# Altium schematic sheet BLDC_Motor_Reverser.SchDoc(BLDC_Motor_Reverser)
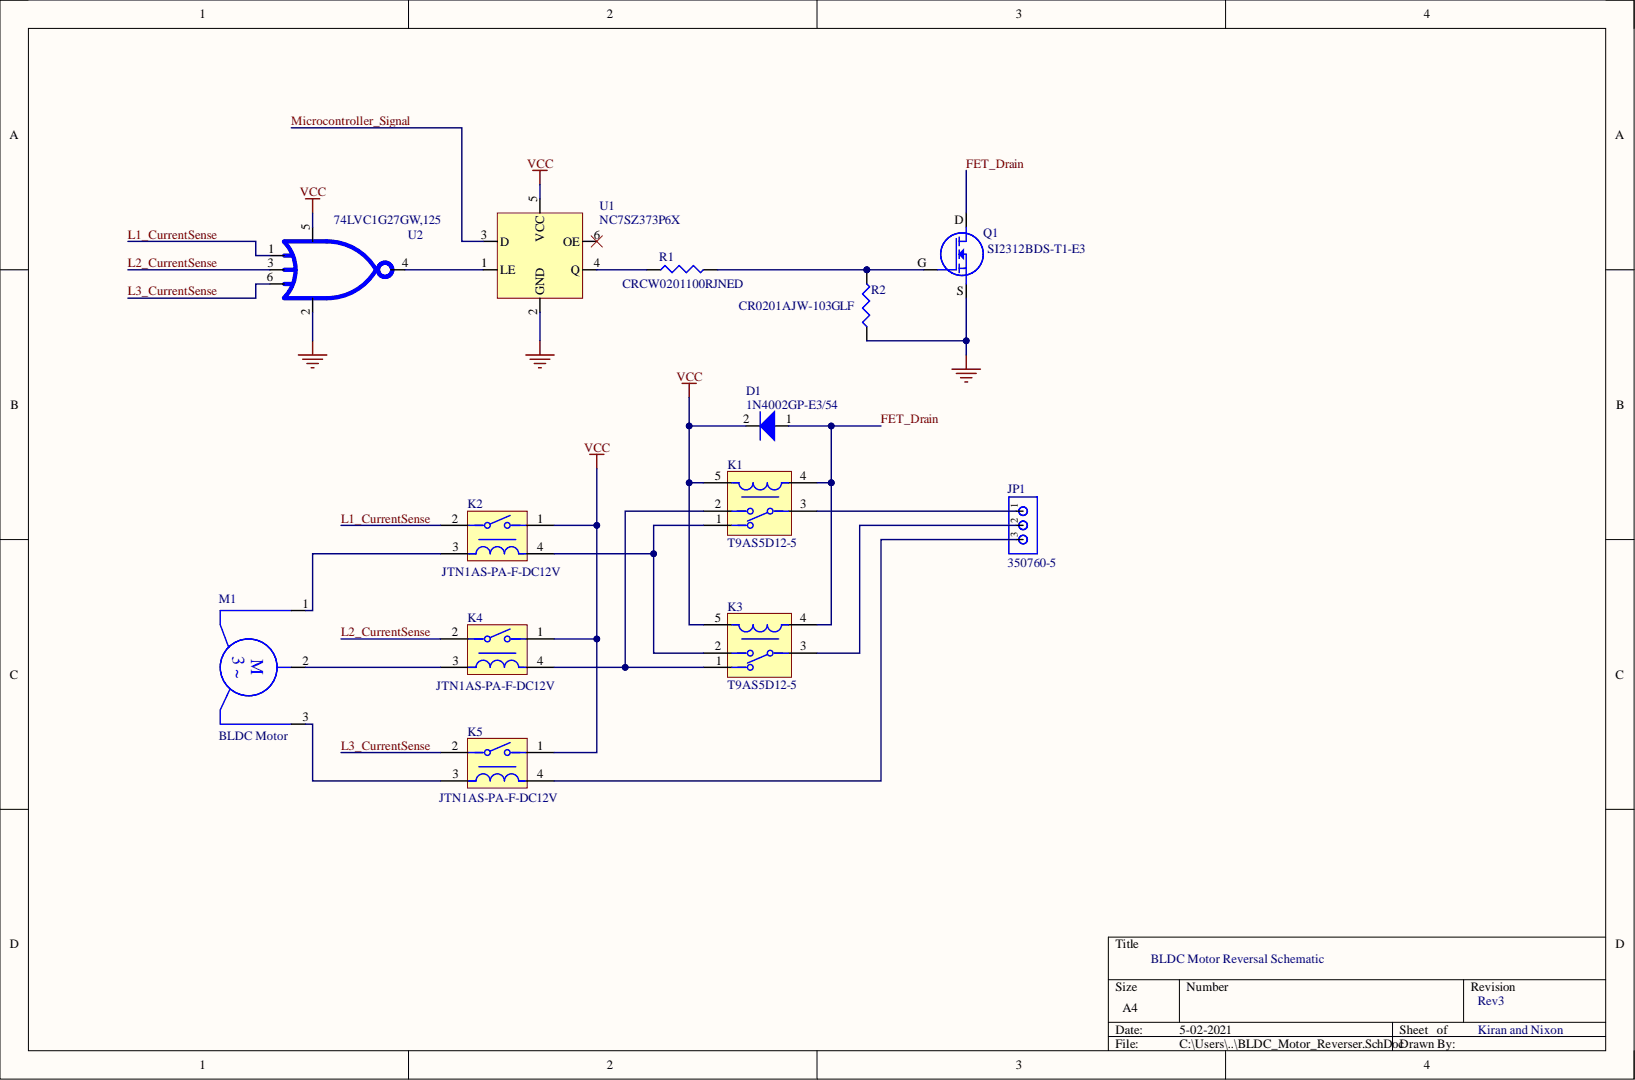
[diagram p0: BLDC_Motor_Reverser.SchDoc]

## Parts
D1  1N4002GP-E3/54
JP1  350760-5
K1  T9AS5D12-5  [FP-T9AS5D12-5-MFG]
K2  JTN1AS-PA-F-DC12V
K3  T9AS5D12-5  [FP-T9AS5D12-5-MFG]
K4  JTN1AS-PA-F-DC12V
K5  JTN1AS-PA-F-DC12V
M1  BLDC Motor
Q1  SI2312BDS-T1-E3
R1  CRCW0201100RJNED
R2  CR0201AJW-103GLF
U1  NC7SZ373P6X
U2  74LVC1G27GW,125

## Nets
GND: Q1.S R2.1 U1.2(GND) U2.2
VCC: D1.2 K1.5 K2.1 K3.5 K4.1 K5.1 U1.5(VCC) U2.5
FET_Drain: D1.1 K1.4 K3.4 Q1.D
L1_CurrentSense: K2.2 U2.1
L2_CurrentSense: K4.2 U2.3
L3_CurrentSense: K5.2 U2.6
Microcontroller_Signal: U1.3(D)
NetJP1_1: JP1.1 K1.3
NetJP1_2: JP1.2 K3.3
NetJP1_3: JP1.3 K5.4
NetK1_1: K1.1 K2.4 K3.2
NetK1_2: K1.2 K3.1 K4.4
NetK2_3: K2.3 M1.1
NetK4_3: K4.3 M1.2
NetK5_3: K5.3 M1.3
NetQ1_G: Q1.G R1.2 R2.2
NetR1_1: R1.1 U1.4(Q)
NetU1_1: U1.1(LE) U2.4

Not connected: U1 6(OE)

## Library data of the parts
D1 = 1N4002GP-E3/54: Flyback Diode for SPDT; Component Kind: Standard; Library Name: BLDC_Motor_Lib.SchLib
K1 K3 = T9AS5D12-5: RELAY GEN PURPOSE SPDT 20A 5V; Coil Current: 200mA; Coil Power: 1W; Coil Resistance: 25R; Coil Type: Non-Latching; Contact Voltage Rating (AC): 277V; Current Rating: 30A; Max Voltage Rating (DC): 28V; Operate Time: 15ms; Relay Type: General Purpose; Coil Voltage (DC): 5V; Component Kind: Standard; Contact Current Rating: 20A; Contact Material: Silver; Height: 20.4mm; Length: 32.5mm; Library Name: BLDC_Motor_Lib.SchLib; Max Operating Temperature: 85°C; Max Voltage Rating (AC): 277V; Min Operating Temperature: -55°C; Mount: Through Hole; Number of Pins: 5; Package Quantity: 50; Packaging: Bulk; Release Time: 15ms; RoHS Compliant: Yes; Series: T9A; Termination: PCB; Throw Configuration: SPDT; Voltage Rating (DC): 5V; Weight: 0.918oz; Width: 27.4mm
K2 K4 K5 = JTN1AS-PA-F-DC12V: POWER RELAY SPST-NO 12VDC, 30A, PC BOARD; Manufacturer: Panasonic; Approvals: UL,CSA; Coil Current: 66.6mA; Coil Power: 800mW; Coil Resistance: 180R; Coil Type: Non-Latching; Coil Voltage (DC): 12V; Life (Hours): 100000Hour; Max Voltage Rating (AC): 277V; Operate Time: 20ms; Relay Function: SPST; Relay Type: General Purpose; Release Time: 10ms; Category: Signal Relays; Component Kind: Standard; ComponentLink1Description: https://datasheet.ciiva.com/19471/761141-19471062.pdf?src-supplier=Jameco; ComponentLink1URL: https://datasheet.ciiva.com/19471/761141-19471062.pdf?src-supplier=Jameco; ComponentLink2Description: https://datasheet.ciiva.com/30126/33ds-61212-en-jtn-30126555.pdf?src-supplier=Onlinecomponents.com; ComponentLink2URL: https://datasheet.ciiva.com/30126/33ds-61212-en-jtn-30126555.pdf?src-supplier=Onlinecomponents.com; ComponentLink3Description: https://datasheet.ciiva.com/8000/1848831-8000169.pdf?src-supplier=Element14; ComponentLink3URL: https://datasheet.ciiva.com/8000/1848831-8000169.pdf?src-supplier=Element14; ComponentLink4Description: https://datasheet.ciiva.com/46407/1794180-46407578.pdf?src-supplier=Element14; ComponentLink4URL: https://datasheet.ciiva.com/46407/1794180-46407578.pdf?src-supplier=Element14; Contact Current Rating: 30A; Contact Material: Tin,Silver; Contact Plating: Silver; Current Rating: 30A; Depth: 26.9mm; Height: 20.2mm; Lead Free: Lead Free; Length: 31.9mm; Library Name: BLDC_Motor_Lib.SchLib; Manufacturer Part Number: JTN1AS-PA-F-DC12V; Max Current Rating: 30A; Max Operating Temperature: 85°C; Min Operating Temperature: -55°C; Mount: Through Hole,PCB; Number of Pins: 4; Package Quantity: 50; Packaging: Bulk; Power Consumption: 800mW; Pricing 1: 1=3.98000, 10=3.72700, 25=3.31280, 50=3.14720, 100=2.98150, 250=2.65024, 500=2.48460, 1000=2.31896 (USD); Radiation Hardening: No; REACH SVHC: No SVHC; RoHS: Yes; Series: JT-N; Stock 1: 28244; Supplier 1: Digi-Key; Supplier Part Number 1: 255-1719-ND; Termination: PC Pin; Throw Configuration: SPST; Voltage Rating (AC): 277V; Weight: 25g
M1 = BLDC_Motor: Component Kind: Standard; Library Name: BLDC_Motor_Lib.SchLib
R1 = CRCW0201100RJNED: 100Ohm resistor (Current Draw Protection Resistor); Component Kind: Standard; Library Name: BLDC_Motor_Lib.SchLib
R2 = CR0201AJW-103GLF: 10KOhm Resistor (Pulldown Res); Component Kind: Standard; Library Name: BLDC_Motor_Lib.SchLib
U1 = NC7SZ373P6X: D-Type Latch; Component Kind: Standard; Library Name: BLDC_Motor_Lib.SchLib
U2 = 74LVC1G27GW,125: 3-Way NOR Gate; Component Kind: Standard; Library Name: BLDC_Motor_Lib.SchLib
JP1 = 350760-5: Component Kind: Standard; Library Name: BLDC_Motor_Lib.SchLib
Q1 = SI2312BDS-T1-E3: Component Kind: Standard; Library Name: BLDC_Motor_Lib.SchLib
